# Altium schematic sheet Power_supplies_V2.SchDoc(Power_supplies_V2)
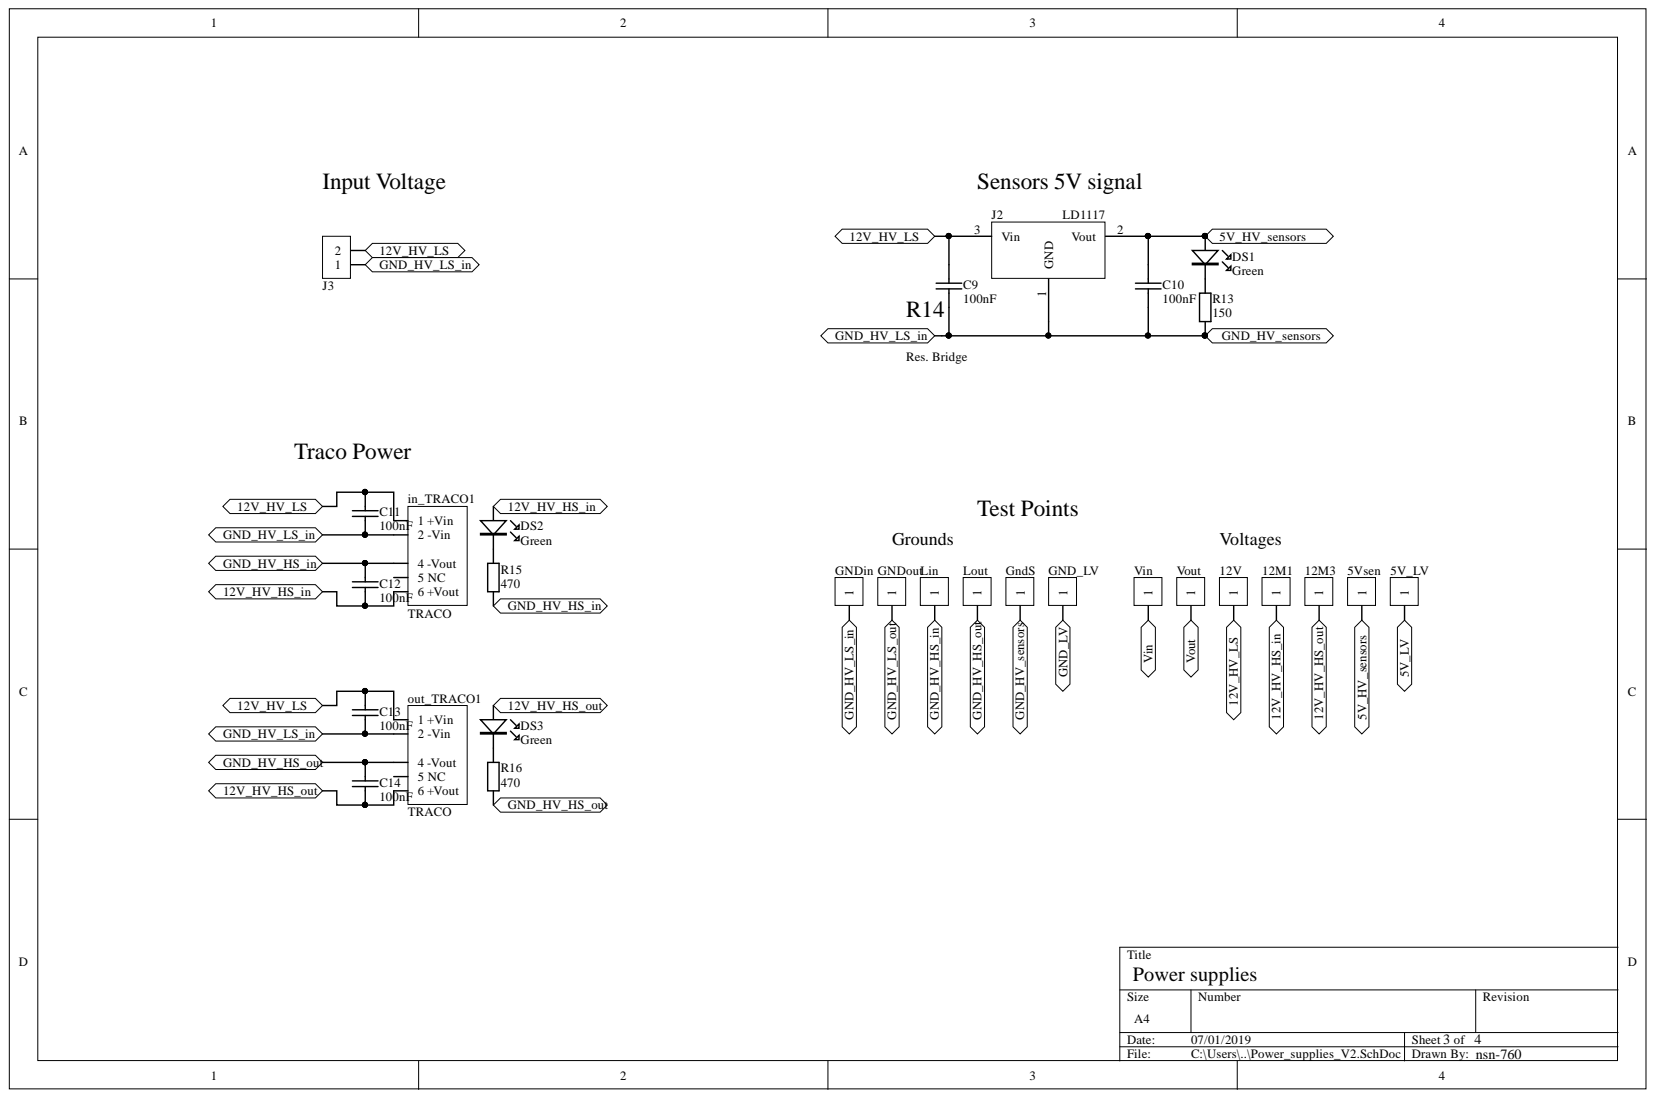
[diagram p0: Power_supplies_V2.SchDoc]

## Parts
5V_LV  Test Point  [Test_Point]
5Vsen  Test Point  [Test_Point]
12M1  Test Point  [Test_Point]
12M3  Test Point  [Test_Point]
12V  Test Point  [Test_Point]
C9  100nF  [1206]
C10  100nF  [1206]
C11  100nF  [1206]
C12  100nF  [1206]
C13  100nF  [1206]
C14  100nF  [1206]
DS1  Green  [Led 2.2mm]
DS2  Green  [Led 2.2mm]
DS3  Green  [Led 2.2mm]
GND_LV  Test Point  [Test_Point]
GNDin  Test Point  [Test_Point]
GNDout  Test Point  [Test_Point]
GndS  Test Point  [Test_Point]
in_TRACO1  TRACO  [TRACO_TMA1212S]
J2  LD1117  [SOT-223_LM1117]
J3  Cleva 2 vias  [Con2_Extron _3.5mmPitch]  (value not drawn)
Lin  Test Point  [Test_Point]
Lout  Test Point  [Test_Point]
out_TRACO1  TRACO  [TRACO_TMA1212S]
R13  150  [1206]
R14  Res. Bridge  [1206]
R15  470  [1206]
R16  470  [1206]
Vin  Test Point  [Test_Point]
Vout  Test Point  [Test_Point]

## Ports
5V_HV_SENSORS, 5V_LV, 12V_HV_HS_IN, 12V_HV_HS_OUT, 12V_HV_LS, GND_HV_HS_IN, GND_HV_HS_OUT, GND_HV_LS_IN, GND_HV_LS_OUT, GND_HV_SENSORS, GND_LV, VIN, VOUT

## Nets
5V_LV: 5V_LV.1 ; port 5V_LV
12V_HV_LS: 12V.1 C9.2 C11.2 C13.2 in_TRACO1.1(1_+Vin) J2.3 J3.2 out_TRACO1.1(1_+Vin) ; port 12V_HV_LS
GND_LV: GND_LV.1 ; port GND_LV
Net5Vsen_1: 5Vsen.1 C10.2 DS1.A J2.2 ; port 5V_HV_SENSORS
Net12M1_1: 12M1.1 C12.1 DS2.A in_TRACO1.6(6_+Vout) ; port 12V_HV_HS_IN
Net12M3_1: 12M3.1 C14.1 DS3.A out_TRACO1.6(6_+Vout) ; port 12V_HV_HS_OUT
NetC9_1: C9.1 C10.1 GndS.1 J2.1 R13.2 R14.2 ; port GND_HV_SENSORS
NetC11_1: C11.1 C13.1 GNDin.1 in_TRACO1.2(2_-Vin) J3.1 out_TRACO1.2(2_-Vin) R14.1 ; port GND_HV_LS_IN
NetC12_2: C12.2 in_TRACO1.4(4_-Vout) Lin.1 R15.2 ; port GND_HV_HS_IN
NetC14_2: C14.2 Lout.1 out_TRACO1.4(4_-Vout) R16.2 ; port GND_HV_HS_OUT
NetDS1_K: DS1.K R13.1
NetDS2_K: DS2.K R15.1
NetDS3_K: DS3.K R16.1
NetGNDout_1: GNDout.1 ; port GND_HV_LS_OUT
NetVin_1: Vin.1 ; port VIN
NetVout_1: Vout.1 ; port VOUT

Not connected: in_TRACO1 5(5_NC); out_TRACO1 5(5_NC)

## Library data of the parts
C9-C14 = Condensador: Component Kind: Standard; Description: Condensador; Library Name: SCH_Lib.SchLib
DS1-DS3 = Led: Component Kind: Standard; Library Name: SCH_Lib.SchLib
in_TRACO1 out_TRACO1 = TRACO: TracoPower TMA1212S; Component Kind: Standard; Library Name: SCH_Lib.SchLib
J2 = LD1117: Linear voltage regulator; Component Kind: Standard; Library Name: SCH_Lib.SchLib
J3 = Con_2: Component Kind: Standard; Library Name: SCH_Lib.SchLib
R13 R15 R16 = Resistencia: Potencia: 1/4W; Component Kind: Standard; Description: Resistencia; Library Name: SCH_Lib.SchLib
R14 = Res. Bridge: Resistencia Bridge; Component Kind: Standard; Library Name: SCH_Lib.SchLib
5V_LV 5Vsen 12M1 12M3 12V GND_LV GNDin GNDout GndS Lin Lout Vin Vout = Test Point: Component Kind: Standard; Library Name: SCH_Lib.SchLib
